# Altium schematic sheet sensor-2.SchDoc(sensor-2)
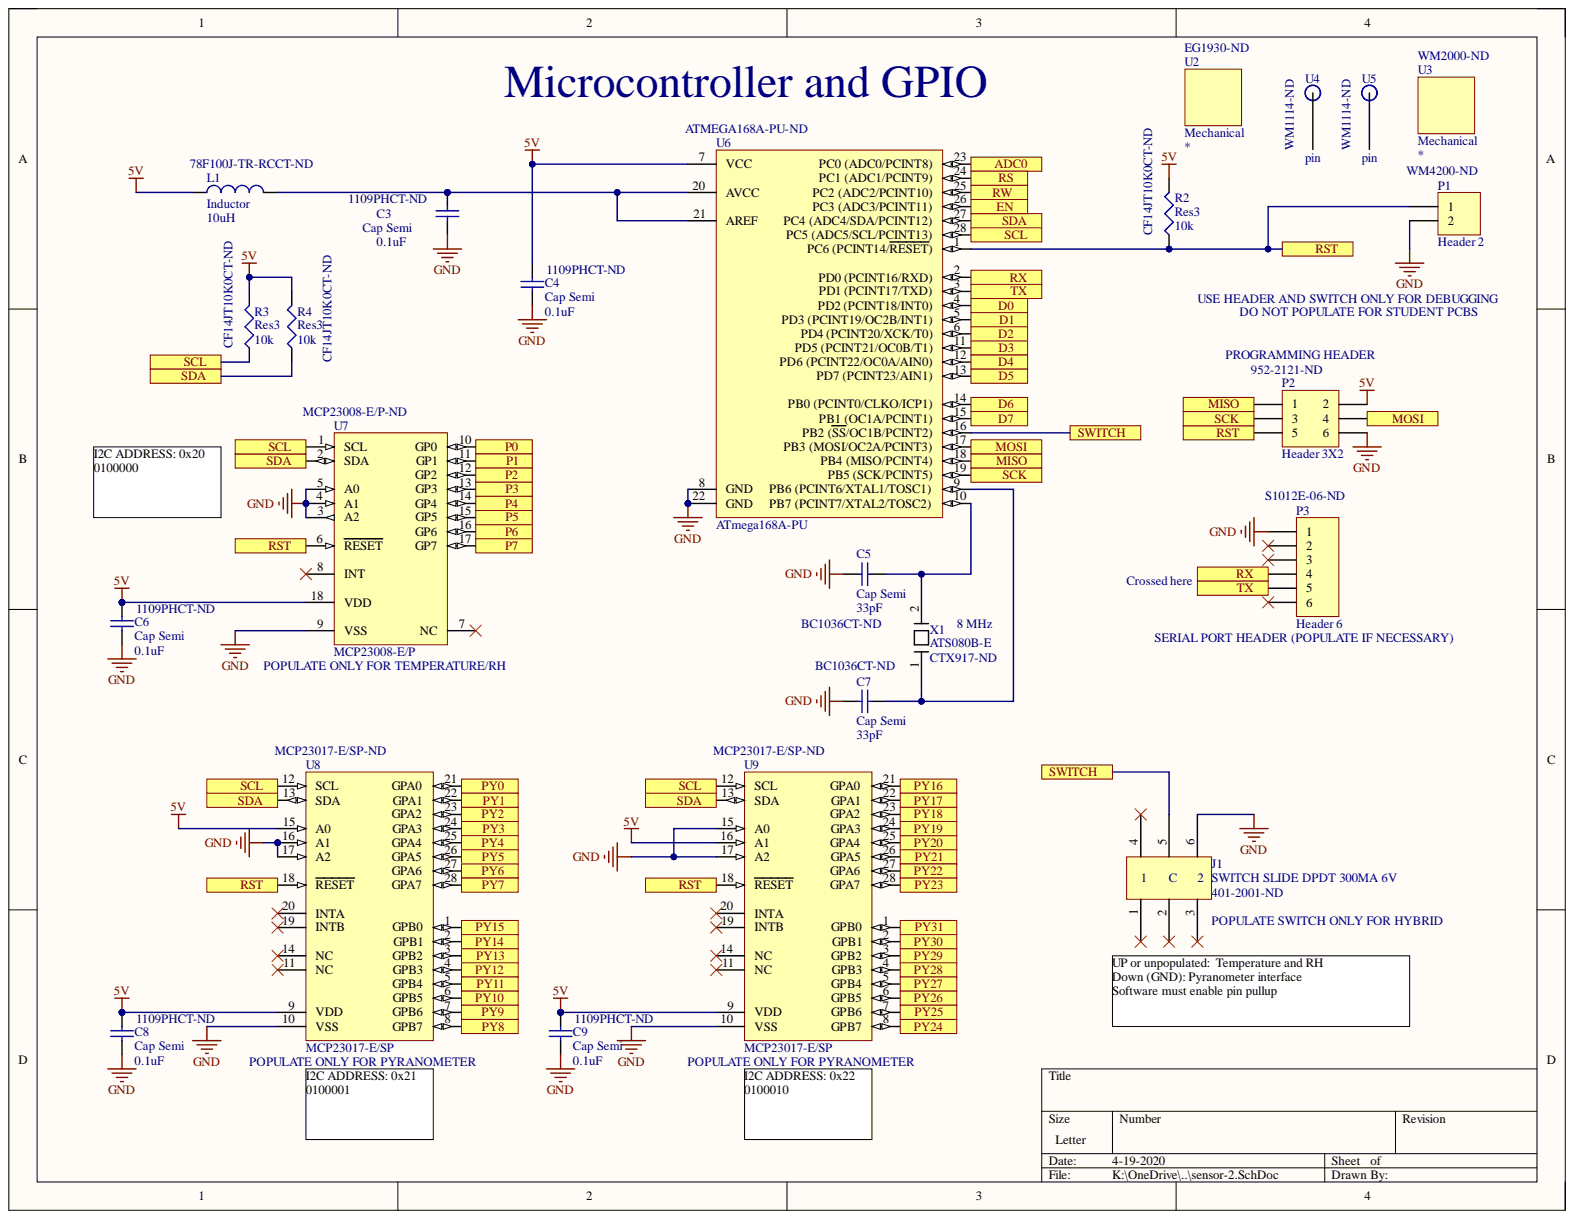
[diagram p0: sensor-2.SchDoc]

## Parts
C3
C4  Cap Semi  value 0.1uF  Supplier Part Number 1: 1109PHCT-ND  [A104K15X7RF5TAA]
C5  Cap Semi  value 33pF  Capacitance: 33pF  [K330J15C0GF5TH5]
C6  Cap Semi  value 0.1uF  Supplier Part Number 1: 1109PHCT-ND  [A104K15X7RF5TAA]
C7  Cap Semi  value 33pF  Capacitance: 33pF  Supplier Part Number 1: BC1036CT-ND  [K330J15C0GF5TH5]
C8  Cap Semi  value 0.1uF  Supplier Part Number 1: 1109PHCT-ND  [A104K15X7RF5TAA]
C9  Cap Semi  value 0.1uF  Supplier Part Number 1: 1109PHCT-ND  [A104K15X7RF5TAA]
J1  SWITCH SLIDE DPDT 300MA 6V  Supplier Part Number 1: 401-2001-ND  [JS202011CQN]
L1  Inductor  value 10uH  Inductance: 10uH  Supplier Part Number 1: 78F100J-TR-RCCT-ND  [78F100J-TR-RC]
P1
P2
P3
R2  Res3  value 10k  Supplier Part Number 1: CF14JT10K0CT-ND  [CF14JT10K0]
R3  Res3  value 10k  Supplier Part Number 1: CF14JT10K0CT-ND  [CF14JT10K0]
R4  Res3  value 10k  [CF14JT10K0]
U2  Mechanical  Supplier Part Number 2: EG1930-ND  (mechanical)
U3  Mechanical  Supplier Part Number 2: WM2000-ND  (mechanical)
U4  pin  Supplier Part Number 1: WM1114-ND  (mechanical)
U5  pin  Supplier Part Number 1: WM1114-ND  (mechanical)
U6  ATmega168A-PU  Supplier Part Number 1: ATMEGA168A-PU-ND  [28P3]
U7  MCP23008-E/P  Supplier Part Number 1: MCP23008-E/P-ND  [PDIP300-P18]
U8  MCP23017-E/SP  Supplier Part Number 1: MCP23017-E/SP-ND  [SPDIP300-SP28]
U9  MCP23017-E/SP  Supplier Part Number 1: MCP23017-E/SP-ND  [SPDIP300-SP28]
X1  ATS080B-E  Supplier Part Number 1: CTX917-ND  [ATS080B-E]

## Ports
ADC0, D0, D1, D2, D3, D4, D5, D6, D7, EN, MISO, MOSI, P0, P1, P2, P3, P4, P5, P6, P7, PY0, PY1, PY2, PY3, PY4, PY5, PY6, PY7, PY8, PY9, PY10, PY11, PY12, PY13, PY14, PY15, PY16, PY17, PY18, PY19, PY20, PY21, PY22, PY23, PY24, PY25, PY26, PY27, PY28, PY29, PY30, PY31, RS, RST, RW, RX, SCK, SCL, SDA, SWITCH, TX

## Nets
5V: C4.2 C6.2 C8.2 C9.2 L1.1 P2.2 R2.2 R3.2 R4.2 U6.7 U7.18 U8.9 U8.15 U9.9 U9.16
GND: C3.1 C4.1 C5.1 C6.1 C7.1 C8.1 C9.1 J1.6 P1.2 P2.6 P3.1 U6.8 U6.22 U7.3 U7.4 U7.5 U7.9 U8.10 U8.16 U8.17 U9.10 U9.15 U9.17
ADC0: U6.23 ; port ADC0
D0: U6.4 ; port D0
D1: U6.5 ; port D1
D2: U6.6 ; port D2
D3: U6.11 ; port D3
D4: U6.12 ; port D4
D5: U6.13 ; port D5
D6: U6.14 ; port D6
D7: U6.15 ; port D7
EN: U6.26 ; port EN
MISO: P2.1 U6.18 ; port MISO
MOSI: P2.4 U6.17 ; port MOSI
P0: U7.10 ; port P0
P1: U7.11 ; port P1
P2: U7.12 ; port P2
P3: U7.13 ; port P3
P4: U7.14 ; port P4
P5: U7.15 ; port P5
P6: U7.16 ; port P6
P7: U7.17 ; port P7
PY0: U8.21 ; port PY0
PY1: U8.22 ; port PY1
PY2: U8.23 ; port PY2
PY3: U8.24 ; port PY3
PY4: U8.25 ; port PY4
PY5: U8.26 ; port PY5
PY6: U8.27 ; port PY6
PY7: U8.28 ; port PY7
PY8: U8.8 ; port PY8
PY9: U8.7 ; port PY9
PY10: U8.6 ; port PY10
PY11: U8.5 ; port PY11
PY12: U8.4 ; port PY12
PY13: U8.3 ; port PY13
PY14: U8.2 ; port PY14
PY15: U8.1 ; port PY15
PY16: U9.21 ; port PY16
PY17: U9.22 ; port PY17
PY18: U9.23 ; port PY18
PY19: U9.24 ; port PY19
PY20: U9.25 ; port PY20
PY21: U9.26 ; port PY21
PY22: U9.27 ; port PY22
PY23: U9.28 ; port PY23
PY24: U9.8 ; port PY24
PY25: U9.7 ; port PY25
PY26: U9.6 ; port PY26
PY27: U9.5 ; port PY27
PY28: U9.4 ; port PY28
PY29: U9.3 ; port PY29
PY30: U9.2 ; port PY30
PY31: U9.1 ; port PY31
RS: U6.24 ; port RS
RST: P1.1 P2.5 R2.1 U6.1 U7.6 U8.18 U9.18 ; port RST
RW: U6.25 ; port RW
RX: P3.4 U6.2 ; port RX
SCK: P2.3 U6.19 ; port SCK
SCL: R3.1 U6.28 U7.1 U8.12 U9.12 ; port SCL
SDA: R4.1 U6.27 U7.2 U8.13 U9.13 ; port SDA
SWITCH: J1.5 U6.16 ; port SWITCH
TX: P3.5 U6.3 ; port TX
NetC3_2: C3.2 L1.2 U6.20 U6.21
NetC5_2: C5.2 U6.10 X1.2
NetC7_2: C7.2 U6.9 X1.1

Not connected: J1 1 2 3 4; P3 2 3 6; U7 7 8; U8 11 14 19 20; U9 11 14 19 20

## Library data of the parts
C4 C6 C8 C9 = Cap Semi: Capacitor (Semiconductor SIM Model); Manufacturer: Vishay BCcomponents; Capacitance: 100nF; Dielectric: X7R; Lead Diameter: 0.5mm; Lead Length: 24.3mm; Tolerance: 10%; Voltage: 50V; Case/Package: Dipped; Component Kind: Standard; ComponentLink1Description: https://datasheet.ciiva.com/145/aseries-145936.pdf?src-supplier=Digi-Key; ComponentLink1URL: https://datasheet.ciiva.com/145/aseries-145936.pdf?src-supplier=Digi-Key; ComponentLink2Description: https://datasheet.ciiva.com/5815/1734588-5815375.pdf?src-supplier=Farnell; ComponentLink2URL: https://datasheet.ciiva.com/5815/1734588-5815375.pdf?src-supplier=Farnell; ComponentLink3Description: https://datasheet.ciiva.com/18442/70122957-18442089.pdf?src-supplier=Allied+Electronics; ComponentLink3URL: https://datasheet.ciiva.com/18442/70122957-18442089.pdf?src-supplier=Allied+Electronics; ComponentLink4Description: https://datasheet.ciiva.com/2988/aseries-2988250.pdf?src-supplier=Avnet; ComponentLink4URL: https://datasheet.ciiva.com/2988/aseries-2988250.pdf?src-supplier=Avnet; ComponentLink5Description: https://datasheet.ciiva.com/17444/aseries-17444908.pdf?src-supplier=Avnet+Europe; ComponentLink5URL: https://datasheet.ciiva.com/17444/aseries-17444908.pdf?src-supplier=Avnet+Europe; ComponentLink6Description: https://datasheet.ciiva.com/46418/1796772-46418252.pdf?src-supplier=Element14; ComponentLink6URL: https://datasheet.ciiva.com/46418/1796772-46418252.pdf?src-supplier=Element14; ComponentLink7Description: https://datasheet.ciiva.com/145/aseries-145935.pdf?src-supplier=Digi-Key; ComponentLink7URL: https://datasheet.ciiva.com/145/aseries-145935.pdf?src-supplier=Digi-Key; Contact Plating: Tin; Diameter: 2.5mm; LatestRevisionDate: 29-May-2009; LatestRevisionNote: IPC-7351 Footprint Added.; Lead Free: Lead Free; Length: 3.8mm; Library Name: Miscellaneous Devices.IntLib; Manufacturer Part Number: A104K15X7RF5TAA; Max Operating Temperature: 125°C; Min Operating Temperature: -55°C; Mount: Through Hole,PCB,Axial; Package Quantity: 1; PackageDescription: Chip Capacitor; PackageReference: C1206; Packaging: Tape and Reel; Pricing 1: 1=0.24000, 10=0.16500, 100=0.09350, 500=0.06598, 1000=0.05773, 2500=0.05498 (USD); Published: 8-Jun-2000; Radiation Hardening: No; REACH SVHC: Unknown; RoHS: Yes; Signal Integrity: Cap Semi; Simulation: CAP; Stock 1: 502711; Supplier 1: Digi-Key; Termination: Axial; Voltage Rating: 50V; Voltage Rating (DC): 50V; Publisher: Altium Limited
C5 C7 = Cap Semi: Capacitor (Semiconductor SIM Model); Manufacturer: Vishay BCcomponents; Dielectric: C0G; Dielectric Material: Ceramic; Lead Length: 30mm; Lead/Base Style: Formed Leads; Tolerance: 5%; Voltage Rating: 50V; Case/Package: Dipped; Component Kind: Standard; ComponentLink1Description: https://datasheet.ciiva.com/145/kseries-145772.pdf?src-supplier=Digi-Key; ComponentLink1URL: https://datasheet.ciiva.com/145/kseries-145772.pdf?src-supplier=Digi-Key; ComponentLink2Description: https://datasheet.ciiva.com/145/kseries-145773.pdf?src-supplier=Digi-Key; ComponentLink2URL: https://datasheet.ciiva.com/145/kseries-145773.pdf?src-supplier=Digi-Key; Depth: 2.5mm; Height - Seated (Max): 0.257inch; LatestRevisionDate: 29-May-2009; LatestRevisionNote: IPC-7351 Footprint Added.; Lead Free: Contains Lead; Lead Pitch: 5mm; Length: 0.157inch; Library Name: Miscellaneous Devices.IntLib; Manufacturer Part Number: K330J15C0GF5TH5; Max Operating Temperature: 125°C; Min Operating Temperature: -55°C; Mount: Through Hole; Number of Pins: 2; Package Quantity: 1; PackageDescription: Chip Capacitor; PackageReference: C1206; Packaging: Tape and Reel; Pricing 1: 1=0.26000, 10=0.17400, 100=0.08830, 500=0.06040, 1000=0.05343 (USD); Published: 8-Jun-2000; Radiation Hardening: No; REACH SVHC: Unknown; RoHS: Yes; Signal Integrity: Cap Semi; Simulation: CAP; Stock 1: 19591; Supplier 1: Digi-Key; Termination: Radial; Voltage Rating (DC): 50V; Width: 0.098inch; Publisher: Altium Limited
  C5: Supplier Part Number 1: BC1036CT-ND
J1 = JS202011CQN: Manufacturer: ITT C&K; Actuator Length: 2mm; Actuator Material: Nylon,Polyamide; Actuator Type: Standard; Contact Current Rating: 100mA; Contact Resistance: 70mR; Electrical Life: 5000Cycles; Illumination Type: Non-Illuminated; Switch Function: On-On; Component Kind: Standard; ComponentLink1Description: https://datasheet.ciiva.com/5280/js-15sept15-5280375.pdf?src-supplier=Mouser; ComponentLink1URL: https://datasheet.ciiva.com/5280/js-15sept15-5280375.pdf?src-supplier=Mouser; ComponentLink2Description: https://datasheet.ciiva.com/22630/1707718-22630695.pdf?src-supplier=Element14; ComponentLink2URL: https://datasheet.ciiva.com/22630/1707718-22630695.pdf?src-supplier=Element14; ComponentLink3Description: https://datasheet.ciiva.com/8082/js-9dec14-8082522.pdf?src-supplier=Avnet; ComponentLink3URL: https://datasheet.ciiva.com/8082/js-9dec14-8082522.pdf?src-supplier=Avnet; ComponentLink4Description: https://datasheet.ciiva.com/2889/js-9dec14-2889166.pdf?src-supplier=Digi-Key; ComponentLink4URL: https://datasheet.ciiva.com/2889/js-9dec14-2889166.pdf?src-supplier=Digi-Key; Contact Material: Copper Alloy; Contact Plating: Silver; Current Rating: 300mA; Depth: 3.6mm; Description: SWITCH SLIDE DPDT 300MA 6V; Flammability Rating: UL94 V-0; Housing Material: Nylon; Lead Free: Lead Free; Length: 9mm; Manufacturer Part Number: JS202011CQN; Max Current Rating: 300mA; Max Operating Temperature: 85°C; Max Voltage Rating (DC): 6V; Min Operating Temperature: -40°C; Mount: Through Hole; Orientation: Vertical; Package Quantity: 1; Packaging: Bulk; Pricing 1: 1=0.43000, 10=0.41600, 25=0.40720, 50=0.36380, 100=0.34650, 250=0.33784, 500=0.28586, 1000=0.27720, 5000=0.24255 (USD); Radiation Hardening: No; REACH SVHC: No SVHC; RoHS: Yes; Stock 1: 30365; Supplier 1: Digi-Key; Switch Travel: 2mm; Termination: PC Pins; Throw Configuration: DPDT; Voltage Rating (DC): 6V; Library Name: CK.IntLib
L1 = Inductor: Manufacturer: Bourns; Code_IEC: 1005; Core Material: Ferrite; Current Rating: 370mA; DC Resistance (DCR): 750mR; Lead Diameter: 0.51mm; Lead/Base Style: Axial; Q Factor: 40; Self Resonant Frequency: 18MHz; Shielding: Unshielded; Test Frequency: 7.9MHz; Tolerance: 5%; Case/Package: Axial; Code_JEITA: 0402; Component Kind: Standard; ComponentLink1Description: https://datasheet.ciiva.com/15161/getdatasheetpartid-486343-15161381.pdf?src-supplier=Verical; ComponentLink1URL: https://datasheet.ciiva.com/15161/getdatasheetpartid-486343-15161381.pdf?src-supplier=Verical; ComponentLink2Description: https://datasheet.ciiva.com/6703/78f-series-6703431.pdf?src-supplier=Digi-Key; ComponentLink2URL: https://datasheet.ciiva.com/6703/78f-series-6703431.pdf?src-supplier=Digi-Key; Composition: Wirewound; Contact Plating: Tin; DC Current: 370mA; Description: Inductor; Diameter: 2.79mm; LatestRevisionDate: 29-May-2009; LatestRevisionNote: IPC-7351 Footprint Added.; Length: 7.11mm; Library Name: Miscellaneous Devices.IntLib; Manufacturer Part Number: 78F100J-TR-RC; Max DC Current: 370mA; Max Operating Temperature: 105°C; Military Standard: Not; Min Operating Temperature: -55°C; Mount: Through Hole; Package Quantity: 5000; PackageDescription: Chip Inductor; PackageReference: 0402-A; Packaging: Tape and Reel; Pricing 1: 1=0.31000, 10=0.27600, 100=0.18980, 500=0.16216, 1000=0.12420, 2500=0.11730 (USD); Published: 8-Jun-2000; Radiation Hardening: No; RoHS: Yes; Signal Integrity: Inductor; Simulation: INDUCTOR; Stock 1: 122934; Supplier 1: Digi-Key; Termination: Axial; Publisher: Altium Limited
R2-R4 = Res3: Resistor; Manufacturer: Stackpole Electronics; Max Power Dissipation: 250mW; Resistance: 10kR; Temperature Coefficient: -500ppm/°C; Tolerance: 5%; Case/Package: Conformal; Component Kind: Standard; ComponentLink1Description: https://www.verical.com/server-webapp/html/getDatasheet?partID=367360&src-supplier=Verical; ComponentLink1URL: https://www.verical.com/server-webapp/html/getDatasheet?partID=367360&src-supplier=Verical; ComponentLink2Description: https://www.seielect.com/catalog/SEI-CF_CFM.pdf?src-supplier=Avnet; ComponentLink2URL: https://www.seielect.com/catalog/SEI-CF_CFM.pdf?src-supplier=Avnet; ComponentLink3Description: http://www.seielect.com/Catalog/SEI-CF_CFM.pdf?src-supplier=Future+Electronics; ComponentLink3URL: http://www.seielect.com/Catalog/SEI-CF_CFM.pdf?src-supplier=Future+Electronics; ComponentLink4Description: https://www.seielect.com/catalog/SEI-Packaging.pdf?src-supplier=Digi-Key; ComponentLink4URL: https://www.seielect.com/catalog/SEI-Packaging.pdf?src-supplier=Digi-Key; ComponentLink5Description: http://components.arrow.com/part/dataview/27619991S9848636N1174?src-supplier=Arrow; ComponentLink5URL: http://components.arrow.com/part/dataview/27619991S9848636N1174?src-supplier=Arrow; Composition: Carbon Film; Contact Plating: Tin,Matte; Diameter: 2.3mm; Features: Flame Retardant Coating; LatestRevisionDate: 29-May-2009; LatestRevisionNote: IPC-7351 Footprint Added.; Lead Free: Lead Free; Length: 6.5mm; Library Name: Miscellaneous Devices.IntLib; Manufacturer Part Number: CF14JT10K0; Max Operating Temperature: 155°C; Military Standard: Not; Min Operating Temperature: -55°C; Mount: Through Hole,Axial; Number of Terminations: 2; Package Quantity: 1; PackageDescription: Chip Resistor; PackageReference: J1-0603; Packaging: Tape and Reel; Power Rating: 250mW; Pricing 1: 1=0.10000, 10=0.04000, 25=0.02880, 50=0.02200, 100=0.01620, 250=0.01236, 500=0.00990, 1000=0.00729 (USD); Published: 8-Jun-2000; Radiation Hardening: No; RoHS: Yes; Signal Integrity: Res; Simulation: RESISTOR; Stock 1: 858538; Supplier 1: Digi-Key; Termination: Axial; Publisher: Altium Limited
  R4: Supplier Part Number 1: CF14JT10K0CT-ND
U2 = Mechanical part: Manufacturer: E-Switch; Manufacturer Part Number: RP3502ARED; Actuator Length: 3mm; Actuator Type: Round, Button; Contact Current Rating: 3A; Contact Resistance: 50mR; Electrical Life: 6000Cycles; Illumination Type: Illuminated; Max Voltage Rating (AC): 250V; Switch Function: Off-Mom; Throw Configuration: SPST; Voltage Rating (AC): 120V; ComponentLink1Description: https://datasheet.ciiva.com/2870/f030012-2870146.pdf?src-supplier=Digi-Key; ComponentLink1URL: https://datasheet.ciiva.com/2870/f030012-2870146.pdf?src-supplier=Digi-Key; ComponentLink2Description: https://datasheet.ciiva.com/5262/f030012-16921-5262232.pdf?src-supplier=Mouser; ComponentLink2URL: https://datasheet.ciiva.com/5262/f030012-16921-5262232.pdf?src-supplier=Mouser; ComponentLink3Description: https://datasheet.ciiva.com/16483/f030012-16483333.pdf?src-supplier=Future+Electronics; ComponentLink3URL: https://datasheet.ciiva.com/16483/f030012-16483333.pdf?src-supplier=Future+Electronics; ComponentLink4Description: https://datasheet.ciiva.com/16192/f030012-16192183.pdf?src-supplier=Onlinecomponents.com; ComponentLink4URL: https://datasheet.ciiva.com/16192/f030012-16192183.pdf?src-supplier=Onlinecomponents.com; Current Rating: 3A; Description: Mechanical Part; Diameter: 14mm; Lead Free: Lead Free; Library Name: mechanical.IntLib; Max Operating Temperature: 65°C; Mechanical Life: 6000Cycles; Min Operating Temperature: -20°C; Mount: Panel,Front; Orientation: Straight; Package Quantity: 1; Packaging: Bulk; Pricing 2: 1=1.80000, 10=1.68400, 25=1.60400, 50=1.52380, 100=1.48370, 250=1.44360, 500=1.36340, 1000=1.28320, 5000=1.08270 (USD); RoHS: Yes; Stock 2: 7662; Supplier 2: Digi-Key; Termination: Solder
U3 = Mechanical part: Manufacturer: Molex; Approvals: UL,CSA; Connector Type: Socket; Gender: Female; Glow Wire Compliant: Non-compliant; Max Current Rating: 4A; Number of Circuits: 2; Pitch: 2.54mm; Voltage Rating: 250V; Color: White; ComponentLink1Description: https://datasheet.ciiva.com/11740/0900766b812c7f18-11740520.pdf?src-supplier=RSComponents; ComponentLink1URL: https://datasheet.ciiva.com/11740/0900766b812c7f18-11740520.pdf?src-supplier=RSComponents; ComponentLink2Description: https://datasheet.ciiva.com/19454/234798-19454255.pdf?src-supplier=Jameco; ComponentLink2URL: https://datasheet.ciiva.com/19454/234798-19454255.pdf?src-supplier=Jameco; ComponentLink3Description: https://datasheet.ciiva.com/3920/67230022013027-crimp-housings-3920657.pdf?src-supplier=Arrow; ComponentLink3URL: https://datasheet.ciiva.com/3920/67230022013027-crimp-housings-3920657.pdf?src-supplier=Arrow; ComponentLink4Description: https://datasheet.ciiva.com/16126/1039760022013027-crimp-housings-16126180.pdf?src-supplier=Onlinecomponents.com; ComponentLink4URL: https://datasheet.ciiva.com/16126/1039760022013027-crimp-housings-16126180.pdf?src-supplier=Onlinecomponents.com; ComponentLink5Description: https://datasheet.ciiva.com/5158/0022013027-crimp-housings-158305-5158363.pdf?src-supplier=Mouser; ComponentLink5URL: https://datasheet.ciiva.com/5158/0022013027-crimp-housings-158305-5158363.pdf?src-supplier=Mouser; ComponentLink6Description: https://datasheet.ciiva.com/30127/0022013027-crimp-housings-30127230.pdf?src-supplier=Onlinecomponents.com; ComponentLink6URL: https://datasheet.ciiva.com/30127/0022013027-crimp-housings-30127230.pdf?src-supplier=Onlinecomponents.com; ComponentLink7Description: https://datasheet.ciiva.com/19473/1965533-19473786.pdf?src-supplier=Jameco; ComponentLink7URL: https://datasheet.ciiva.com/19473/1965533-19473786.pdf?src-supplier=Jameco; ComponentLink8Description: https://datasheet.ciiva.com/7920/1875697-7920678.pdf?src-supplier=Element14; ComponentLink8URL: https://datasheet.ciiva.com/7920/1875697-7920678.pdf?src-supplier=Element14; ComponentLink9Description: https://datasheet.ciiva.com/16660/0022013027-crimp-housings-16660629.pdf?src-supplier=Avnet+Europe; ComponentLink9URL: https://datasheet.ciiva.com/16660/0022013027-crimp-housings-16660629.pdf?src-supplier=Avnet+Europe; ComponentLink10Description: https://datasheet.ciiva.com/15217/getdatasheetpartid-175294-15217511.pdf?src-supplier=Verical; ComponentLink10URL: https://datasheet.ciiva.com/15217/getdatasheetpartid-175294-15217511.pdf?src-supplier=Verical; ComponentLink11Description: https://datasheet.ciiva.com/10401/0022013027-crimp-housings-10401658.pdf?src-supplier=Avnet; ComponentLink11URL: https://datasheet.ciiva.com/10401/0022013027-crimp-housings-10401658.pdf?src-supplier=Avnet; Contact Material: Bronze,Brass; Depth: 12.7mm; Description: Mechanical Part; Flammability Rating: UL94 V-0; Halogen Free: Low Halogen; Height: 4.82mm; Housing Color: White; Housing Material: Nylon; Lead Free: Lead Free; Length: 5.64mm; Library Name: mechanical.IntLib; Manufacturer Part Number: 22-01-3027; Max Operating Temperature: 75°C; Min Operating Temperature: 0°C; Mount: Cable; Number of Contacts: 2; Number of Positions: 2; Number of Rows: 1; Orientation: Straight; Packaging: Bulk; Pricing 2: 1=0.13000, 10=0.12000, 25=0.08920, 50=0.07660, 100=0.07400, 250=0.06376, 500=0.06120, 1000=0.05355, 2500=0.04845 (USD); Radiation Hardening: No; REACH SVHC: Unknown; RoHS: Yes; Stock 2: 100786; Supplier 2: Digi-Key; Termination: Crimp; Width: 4.82mm
U4 U5 = pin: Manufacturer: Molex; Manufacturer Part Number: 0008500114; Contact Resistance: 20mR; Gender: Female; Max Current Rating: 4A; Max Voltage Rating (AC): 250V; Shielding: Unshielded; Wire Gauge (Max): 30AWG; Wire Gauge (Min): 22AWG; ComponentLink1Description: https://datasheet.ciiva.com/6806/008550101-sd-6806024.pdf?src-supplier=Digi-Key; ComponentLink1URL: https://datasheet.ciiva.com/6806/008550101-sd-6806024.pdf?src-supplier=Digi-Key; ComponentLink2Description: https://datasheet.ciiva.com/3916/96180008500114-crimp-terminals-3916636.pdf?src-supplier=Arrow; ComponentLink2URL: https://datasheet.ciiva.com/3916/96180008500114-crimp-terminals-3916636.pdf?src-supplier=Arrow; Contact Material: Brass; Contact Plating: Tin; Depth: 2mm; Description: pin; Length: 9.7mm; Library Name: mechanical.IntLib; Mount: Cable; Orientation: Straight; Packaging: Bulk; RoHS: Yes; Stock 1: 0; Supplier 1: Digi-Key; Termination: Crimp; Wire/Cable Gauge: 30AWG
U6 = CMP-0095-00025-2: 8-bit AVR Microcontroller, 16KB Flash, 512 Bytes EEPROM, 1KB SRAM, 28-pin PDIP, Industrial Grade (-40°C to 85°C); Manufacturer: Microchip / Atmel; Data Bus Width: 8b; Frequency: 20MHz; Interface: I2C,SPI,UART,USART; Max Supply Voltage: 5.5V; Min Supply Voltage: 1.8V; Number of ADC Channels: 6; Number of I2C Channels: 1; Number of I/Os: 23; Number of Timers/Counters: 3; Oscillator Type: Internal; Peripherals: Brown-out Detect/Reset,POR,PWM,WDT; Watchdog Timer: Yes; Case/Package: PDIP; Component Kind: Standard; ComponentLink1Description: Manufacturer URL; ComponentLink1URL: http://www.atmel.com/; ComponentLink2Description: ATmega48A/48PA/88A/88PA/168A/168PA/328/328P Datasheet; ComponentLink2URL: http://www.atmel.com/dyn/resources/prod_documents/8271.pdf; ComponentLink3Description: ATmega48A/48PA/88A/88PA/168A/168PA/328/328P Summary; ComponentLink3URL: http://www.atmel.com/dyn/resources/prod_documents/8271S.pdf; ComponentLink4Description: https://datasheet.ciiva.com/1157/1691443-1157401.pdf?src-supplier=Element14; ComponentLink4URL: https://datasheet.ciiva.com/1157/1691443-1157401.pdf?src-supplier=Element14; Contact Plating: Tin,Matte; Core Architecture: AVR; DatasheetVersion: revD, May-2011; Height: 4.572mm; Lead Free: Lead Free; Length: 34.8mm; Library Name: Altium Content Vault; Manufacturer Part Number: ATMEGA168A-PU; Max Frequency: 20MHz; Max Operating Temperature: 85°C; Memory Size: 16KB; Memory Type: FLASH; Min Operating Temperature: -40°C; Mount: Through Hole; Number of Pins: 28; Number of Programmable I/O: 23; Number of PWM Channels: 6; Number of SPI Channels: 1; Package Quantity: 14; PackageDescription: 28-lead (0.300"/7.62mm Wide) Plastic Dual Inline Package (PDIP); PackageReference: 28P3; PackageVersion: revB, Sep-2001; Packaging: Rail/Tube; Pricing 1: 1=2.19000, 25=2.00840, 100=1.81280 (USD); Radiation Hardening: No; RAM Size: 1KB; REACH SVHC: No SVHC; RevisionNote: DatasheetVersion updated. URLs added.; RoHS: Yes; Stock 1: 14167; Supplier 1: Digi-Key; Width: 7.49mm
U7 = CMP-0186-00005-2: 8-Bit I/O Expander with Serial Interface, 18-Pin PDIP, Extended Temperature; Manufacturer: Microchip; Frequency: 1.7MHz; Interface: I2C,Serial; Max Supply Voltage: 5.5V; Min Supply Voltage: 4.5V; Nominal Supply Current: 1mA; Number of Bits: 8; Number of Channels: 1; Output Current: 25mA; Power Dissipation: 700mW; Case/Package: PDIP; Code_JEDEC: MS-001; Component Kind: Standard; ComponentLink1Description: Manufacturer URL; ComponentLink1URL: http://www.microchip.com/; ComponentLink2Description: Datasheet; ComponentLink2URL: http://ww1.microchip.com/downloads/en/DeviceDoc/21919e.pdf; ComponentLink3Description: Package Specification; ComponentLink3URL: http://www.microchip.com/stellent/groups/techpub_sg/documents/packagingspec/en012702.pdf; ComponentLink4Description: https://datasheet.ciiva.com/19457/2161094-19457129.pdf?src-supplier=Jameco; ComponentLink4URL: https://datasheet.ciiva.com/19457/2161094-19457129.pdf?src-supplier=Jameco; ComponentLink5Description: https://datasheet.ciiva.com/4826/21919b-65915-4826338.pdf?src-supplier=Mouser; ComponentLink5URL: https://datasheet.ciiva.com/4826/21919b-65915-4826338.pdf?src-supplier=Mouser; ComponentLink6Description: https://datasheet.ciiva.com/1780/21919e-1780549.pdf?src-supplier=Digi-Key; ComponentLink6URL: https://datasheet.ciiva.com/1780/21919e-1780549.pdf?src-supplier=Digi-Key; ComponentLink7Description: https://datasheet.ciiva.com/14621/70046134-14621757.pdf?src-supplier=Allied+Electronics; ComponentLink7URL: https://datasheet.ciiva.com/14621/70046134-14621757.pdf?src-supplier=Allied+Electronics; ComponentLink8Description: https://datasheet.ciiva.com/3149/21919e-3149151.pdf?src-supplier=Avnet; ComponentLink8URL: https://datasheet.ciiva.com/3149/21919e-3149151.pdf?src-supplier=Avnet; ComponentLink9Description: https://datasheet.ciiva.com/1495/12174-1495862.pdf?src-supplier=Element14; ComponentLink9URL: https://datasheet.ciiva.com/1495/12174-1495862.pdf?src-supplier=Element14; DatasheetVersion: revE, Aug-2007; Lead Free: Lead Free; Library Name: Altium Content Vault; Manufacturer Part Number: MCP23008-E/P; Max Frequency: 1.7MHz; Max Operating Temperature: 125°C; Min Operating Temperature: -40°C; Mount: Through Hole; Number of I/Os: 8; Number of Pins: 18; Package Quantity: 25; PackageDescription: 18-Lead Plastic Dual In-Line (P) - 300 mil Body [PDIP]; PackageReference: PDIP300-P18; PackageVersion: revBB, Aug-2009; Packaging: Rail/Tube; Pricing 1: 1=1.05000, 25=0.87560, 100=0.80340 (USD); REACH SVHC: No SVHC; RevisionNote: URLs added.; RoHS: Yes; Stock 1: 809; Supplier 1: Digi-Key
U8 U9 = CMP-0186-00081-2: 16-Bit I/O Expander with Serial Interface, 28-Pin SPDIP, Extended Temperature; Manufacturer: Microchip; Frequency: 1.7MHz; Interface: I2C,Serial; Max Supply Voltage: 5.5V; Min Supply Voltage: 1.8V; Nominal Supply Current: 1mA; Number of Bits: 16; Number of Channels: 1; Case/Package: DIP; Component Kind: Standard; ComponentLink1Description: Manufacturer URL; ComponentLink1URL: http://www.microchip.com/; ComponentLink2Description: Datasheet; ComponentLink2URL: http://ww1.microchip.com/downloads/en/DeviceDoc/21952b.pdf; ComponentLink3Description: Package Specification; ComponentLink3URL: http://www.microchip.com/stellent/groups/techpub_sg/documents/packagingspec/en012702.pdf; ComponentLink4Description: https://datasheet.ciiva.com/1495/12179-1495877.pdf?src-supplier=Element14; ComponentLink4URL: https://datasheet.ciiva.com/1495/12179-1495877.pdf?src-supplier=Element14; ComponentLink5Description: https://datasheet.ciiva.com/3149/21952b-3149159.pdf?src-supplier=Avnet; ComponentLink5URL: https://datasheet.ciiva.com/3149/21952b-3149159.pdf?src-supplier=Avnet; ComponentLink6Description: https://datasheet.ciiva.com/16350/filehandler-aspxddocname-en023709-16350808.pdf?src-supplier=Future+Electronics; ComponentLink6URL: https://datasheet.ciiva.com/16350/filehandler-aspxddocname-en023709-16350808.pdf?src-supplier=Future+Electronics; ComponentLink7Description: https://datasheet.ciiva.com/18405/70046137-18405547.pdf?src-supplier=Allied+Electronics; ComponentLink7URL: https://datasheet.ciiva.com/18405/70046137-18405547.pdf?src-supplier=Allied+Electronics; ComponentLink8Description: https://datasheet.ciiva.com/1780/21952b-1780556.pdf?src-supplier=Digi-Key; ComponentLink8URL: https://datasheet.ciiva.com/1780/21952b-1780556.pdf?src-supplier=Digi-Key; DatasheetVersion: revB, Feb-2007; Lead Free: Lead Free; Library Name: Altium Content Vault; Manufacturer Part Number: MCP23017-E/SP; Max Frequency: 1.7MHz; Max Operating Temperature: 125°C; Min Operating Temperature: -40°C; Mount: Through Hole,Surface Mount; Number of I/Os: 16; Number of Pins: 28; PackageDescription: 28-Lead Skinny Plastic Dual In-Line (SP) - 300 mil Body [SPDIP]; PackageReference: SPDIP300-SP28; PackageVersion: revBB, Aug-2009; Packaging: Rail/Tube; Pricing 1: 1=1.24000, 25=1.03000, 100=0.93730 (USD); REACH SVHC: No SVHC; RevisionNote: URLs added.; RoHS: Yes; Stock 1: 2595; Supplier 1: Digi-Key
X1 = ATS080B-E: CRYSTAL 8.0000MHZ 18PF T/H; Manufacturer: CTS; ESR (Equivalent Series Resistance): 60R; Frequency: 8MHz; Frequency Stability: 50ppm; Frequency Tolerance: 30ppm; Component Kind: Standard; ComponentLink1Description: https://datasheet.ciiva.com/859/008-0309-0-859649.pdf?src-supplier=Digi-Key; ComponentLink1URL: https://datasheet.ciiva.com/859/008-0309-0-859649.pdf?src-supplier=Digi-Key; ComponentLink2Description: https://datasheet.ciiva.com/3176/008-0309-0-3176342.pdf?src-supplier=Avnet; ComponentLink2URL: https://datasheet.ciiva.com/3176/008-0309-0-3176342.pdf?src-supplier=Avnet; ComponentLink3Description: https://datasheet.ciiva.com/4945/008-0309-0-32667-4945355.pdf?src-supplier=Mouser; ComponentLink3URL: https://datasheet.ciiva.com/4945/008-0309-0-32667-4945355.pdf?src-supplier=Mouser; Contact Plating: Copper,Tin,Silver; Height: 0.145inch; Length: 0.427inch; Library Name: cts.IntLib; Load Capacitance: 18pF; Manufacturer Part Number: ATS080B-E; Max Operating Temperature: 85°C; Min Operating Temperature: -40°C; Mount: Through Hole; Number of Pins: 2; Package Quantity: 100; Packaging: Bulk; Pricing 1: 1=0.38000, 10=0.31500, 50=0.28360, 100=0.25200, 500=0.23940, 1000=0.20160, 2500=0.18900, 5000=0.18270, 10000=0.17640 (USD); RoHS: Yes; Stock 1: 29517; Supplier 1: Digi-Key; Termination: Radial; Tolerance: 30ppm; Width: 0.177inch
